# Altium schematic sheet CaRe_Schematic_SMD_TOP_PANEL.SchDoc(CaRe_Schematic_SMD_TOP_PANEL)
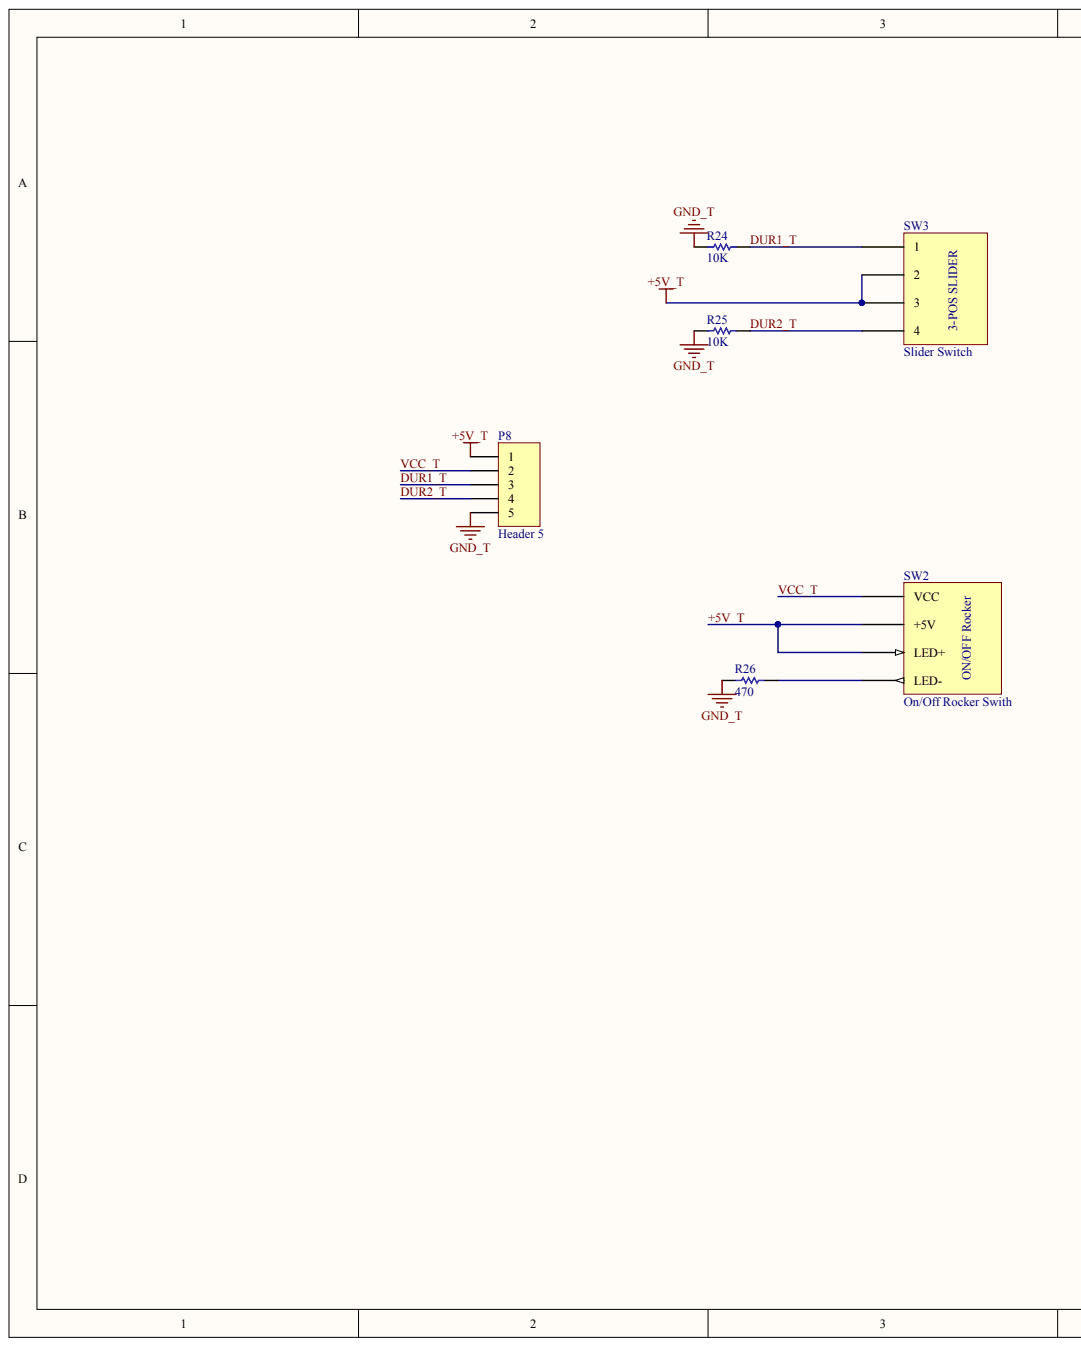
[diagram p0: CaRe_Schematic_SMD_TOP_PANEL.SchDoc, left]
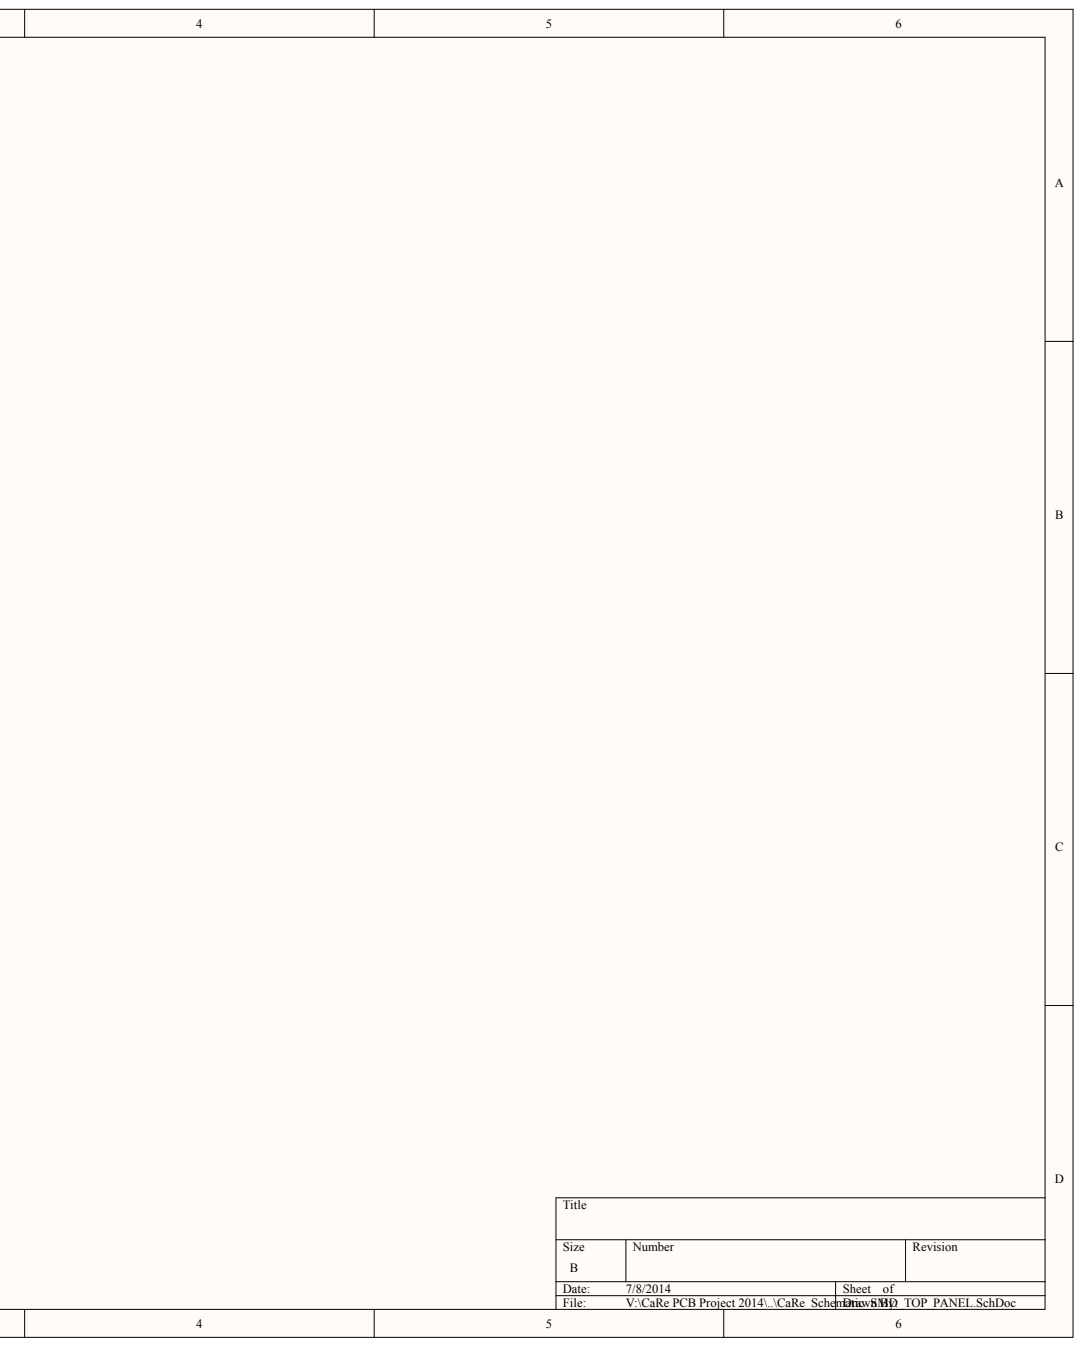
[diagram p1: CaRe_Schematic_SMD_TOP_PANEL.SchDoc, right]

## Parts
P8  Header 5
R24  value 10K  (hidden comment: Resistor)
R25  value 10K  (hidden comment: Resistor)
R26  value 470  (hidden comment: Resistor)
SW2  On/Off Rocker Swith
SW3  Slider Switch

## Nets
GND_T: P8.5 R24.1 R25.1 R26.1
+5V_T: P8.1 SW2.2(+5V) SW2.3(LED+) SW3.2 SW3.3
DUR1_T: P8.3 R24.2 SW3.1
DUR2_T: P8.4 R25.2 SW3.4
VCC_T: P8.2 SW2.1(VCC)
NetR26_2: R26.2 SW2.4(LED-)

## Library data of the parts
P8 R24-R26 SW2 SW3 = (no symbol name)
  P8: LatestRevisionDate: 17-Jul-2002; LatestRevisionNote: Re-released for DXP Platform.
  R24-R26: Published: 8-Jun-2000; LatestRevisionDate: 17-Jul-2002; LatestRevisionNote: Re-released for DXP Platform.; PackageReference: AXIAL-0.3; PackageDescription: Axial Device, Thru-Hole; 2 Leads; 0.3 in Pin Spacing
  P8 R24-R26: Publisher: Altium Limited
